# Altium schematic sheet GPS.SchDoc
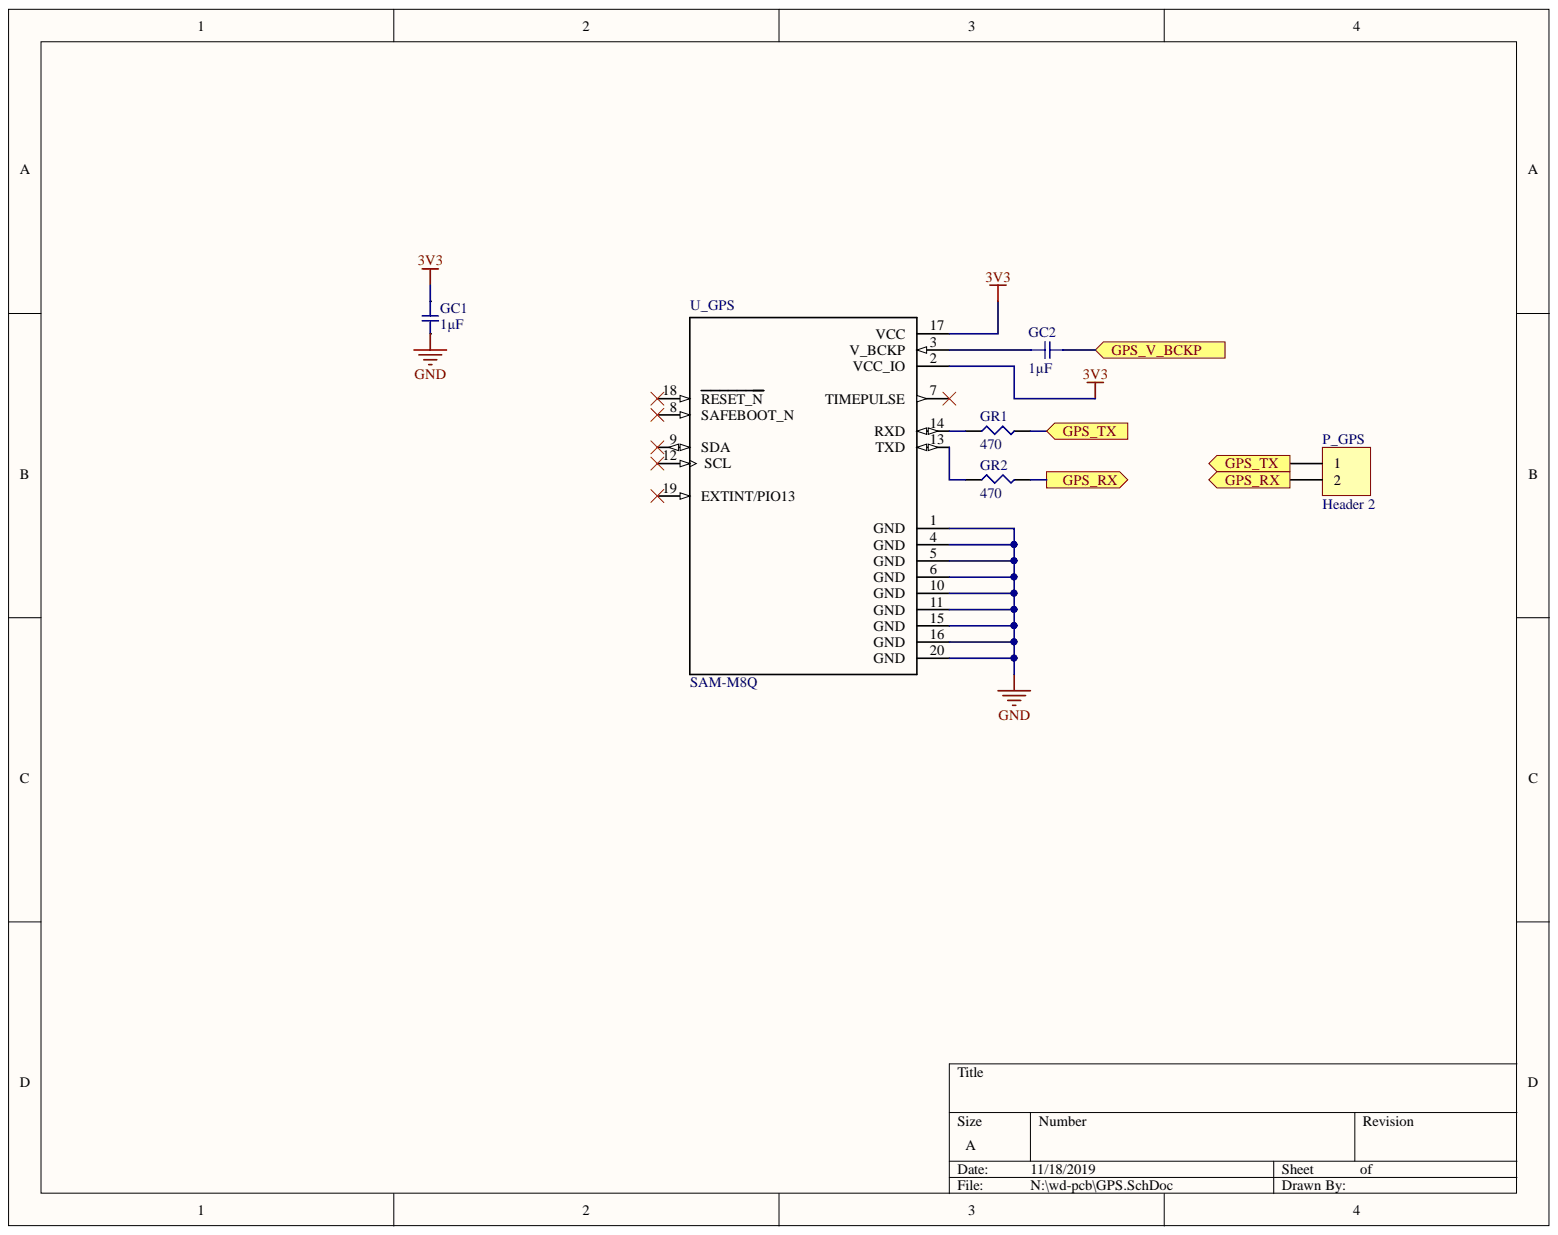
[diagram p0: GPS.SchDoc]

## Parts
GC1  1µF  [SMD-0603C]
GC2  1µF  [SMD-0603C]
GR1  470  [SMD-0603-RES]
GR2  470  [SMD-0603-RES]
P_GPS  Header 2  [HDR1X2]
U_GPS  SAM-M8Q  [*XCVR_SAM-M8Q]

## Ports
GPS_RX, GPS_TX, GPS_V_BCKP

## Nets
3V3: GC1.1 U_GPS.2(VCC_IO) U_GPS.17(VCC)
GND: GC1.2 U_GPS.1(GND) U_GPS.4(GND) U_GPS.5(GND) U_GPS.6(GND) U_GPS.10(GND) U_GPS.11(GND) U_GPS.15(GND) U_GPS.16(GND) U_GPS.20(GND)
GPS_RX: GR2.2 P_GPS.2 ; port GPS_RX
GPS_TX: GR1.2 P_GPS.1 ; port GPS_TX
GPS_V_BCKP: GC2.2 ; port GPS_V_BCKP
NetGC2_1: GC2.1 U_GPS.3(V_BCKP)
NetGR1_1: GR1.1 U_GPS.14(RXD)
NetGR2_1: GR2.1 U_GPS.13(TXD)

Not connected: U_GPS 7(TIMEPULSE) 8(SAFEBOOT_N) 9(SDA) 12(SCL) 18(RESET_N) 19(EXTINT/PIO13)

## Library data of the parts
GC1 GC2 = GRM188R61A105KA61D: CAP CER 1UF 10V X5R 0603; Manufacturer: Murata Electronics North America; @ qty: 0; Minimum Quantity: 1; Tolerance: ±10%; Unit Price (USD): 0.1; Applications: General Purpose; Component Kind: Standard; Datasheets: http://www.murata.com/~/media/webrenewal/support/library/catalog/products/capacitor/mlcc/c02e.pdf; Digi-Key Part Number: 490-1543-1-ND; Factory Stock: 0; Features: -; Height - Seated (Max): -; Image: //media.digikey.com/Renders/Murata%20Renders/ZRB-SERIES-0402.jpg; Lead Spacing: -; Lead Style: -; Library Name: capacitor_murata_0603.IntLib; Mounting Type: Surface Mount, MLCC; Operating Temperature: -55°C ~ 85°C; Package / Case: 0603 (1608 Metric); Packaging: Cut Tape (CT); Part Number: GRM188R61A105KA61D; Part Status: Active; Quantity Available: 2638296; Ratings: -; Series: GRM; Size / Dimension: 0.063" L x 0.031" W (1.60mm x 0.80mm); Temperature Coefficient: X5R; Thickness (Max): 0.035" (0.90mm); Value: 1µF; Voltage: 10V
GR1 GR2 = ERJ-3EKF4700V: RES SMD 470 OHM 1% 1/10W 0603; Manufacturer: Panasonic Electronic Components; @ qty: 1500; Factory Stock: 0; Minimum Quantity: 1; Power (Watts): 0.1W, 1/10W; Temperature Coefficient: ±100ppm/°C; Unit Price (USD): 0.00379; Component Kind: Standard; Composition: Thick Film; Datasheets: http://industrial.panasonic.com/www-cgi/jvcr13pz.cgi?E+PZ+3+AOA0002+ERJ3EKF4700V+7+WW; Digi-Key Part Number: P470HCT-ND; Failure Rate: -; Features: Automotive AEC-Q200; Height - Seated (Max): 0.022" (0.55mm); Image: //media.digikey.com/Photos/Panasonic%20Photos/MFG_ERJ-P6W%20Series.jpg; Library Name: Panasonic_ERJ_0603.IntLib; Number of Terminations: 2; Operating Temperature: -55°C ~ 155°C; Package / Case: 0603 (1608 Metric); Packaging: Cut Tape (CT); Part Number: ERJ-3EKF4700V; Part Status: Active; Quantity Available: 553629; Series: ERJ; Size / Dimension: 0.063" L x 0.031" W (1.60mm x 0.80mm); Supplier Device Package: 0603; Tolerance: ±1%; Value: 470
P_GPS = Header 2: Header, 2-Pin; Component Kind: Standard; LatestRevisionDate: 17-Jul-2002; LatestRevisionNote: Re-released for DXP Platform.; Library Name: Miscellaneous Connectors.IntLib; Signal Integrity: Connector; Publisher: Altium Limited
U_GPS = SAM-M8Q: u-Blox M8 Gnss Antenna Module; MF: U-Blox America; Component Kind: Standard; Digi-Key_Part_Number: 672-1054-1-ND; Digi-Key_Purchase_URL: https://www.digikey.com/product-detail/en/u-blox-america-inc/SAM-M8Q-0-10/672-1054-1-ND/7393573?utm_source=snapeda&utm_medium=aggregator&utm_campaign=symbol; Library Name: SAM-M8Q.IntLib; MP: SAM-M8Q; Package: SMD-20 U-Blox America; SnapEDA_Link: https://www.snapeda.com/parts/SAM-M8Q/U-Blox%20America%20Inc./view-part/?ref=snap
